# Altium schematic sheet Debug UART.SchDoc
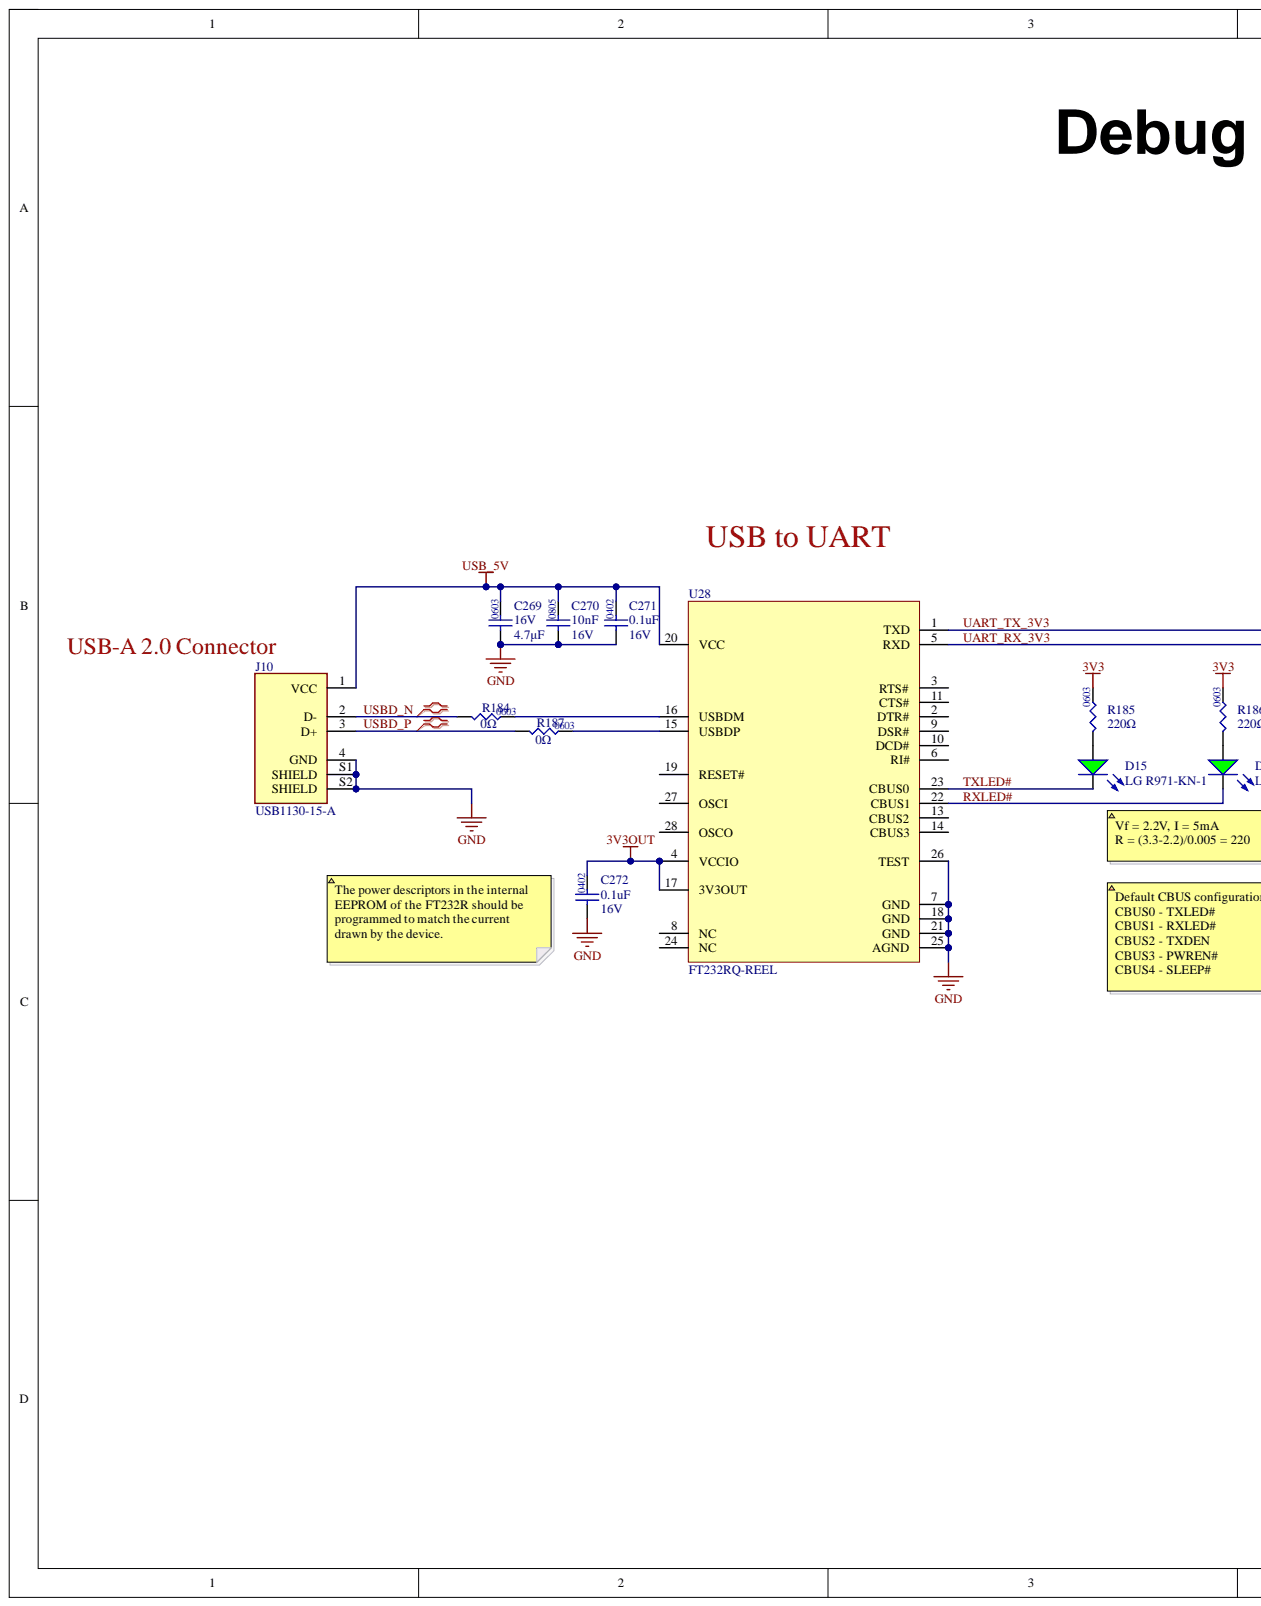
[diagram p0: Debug UART.SchDoc, left]
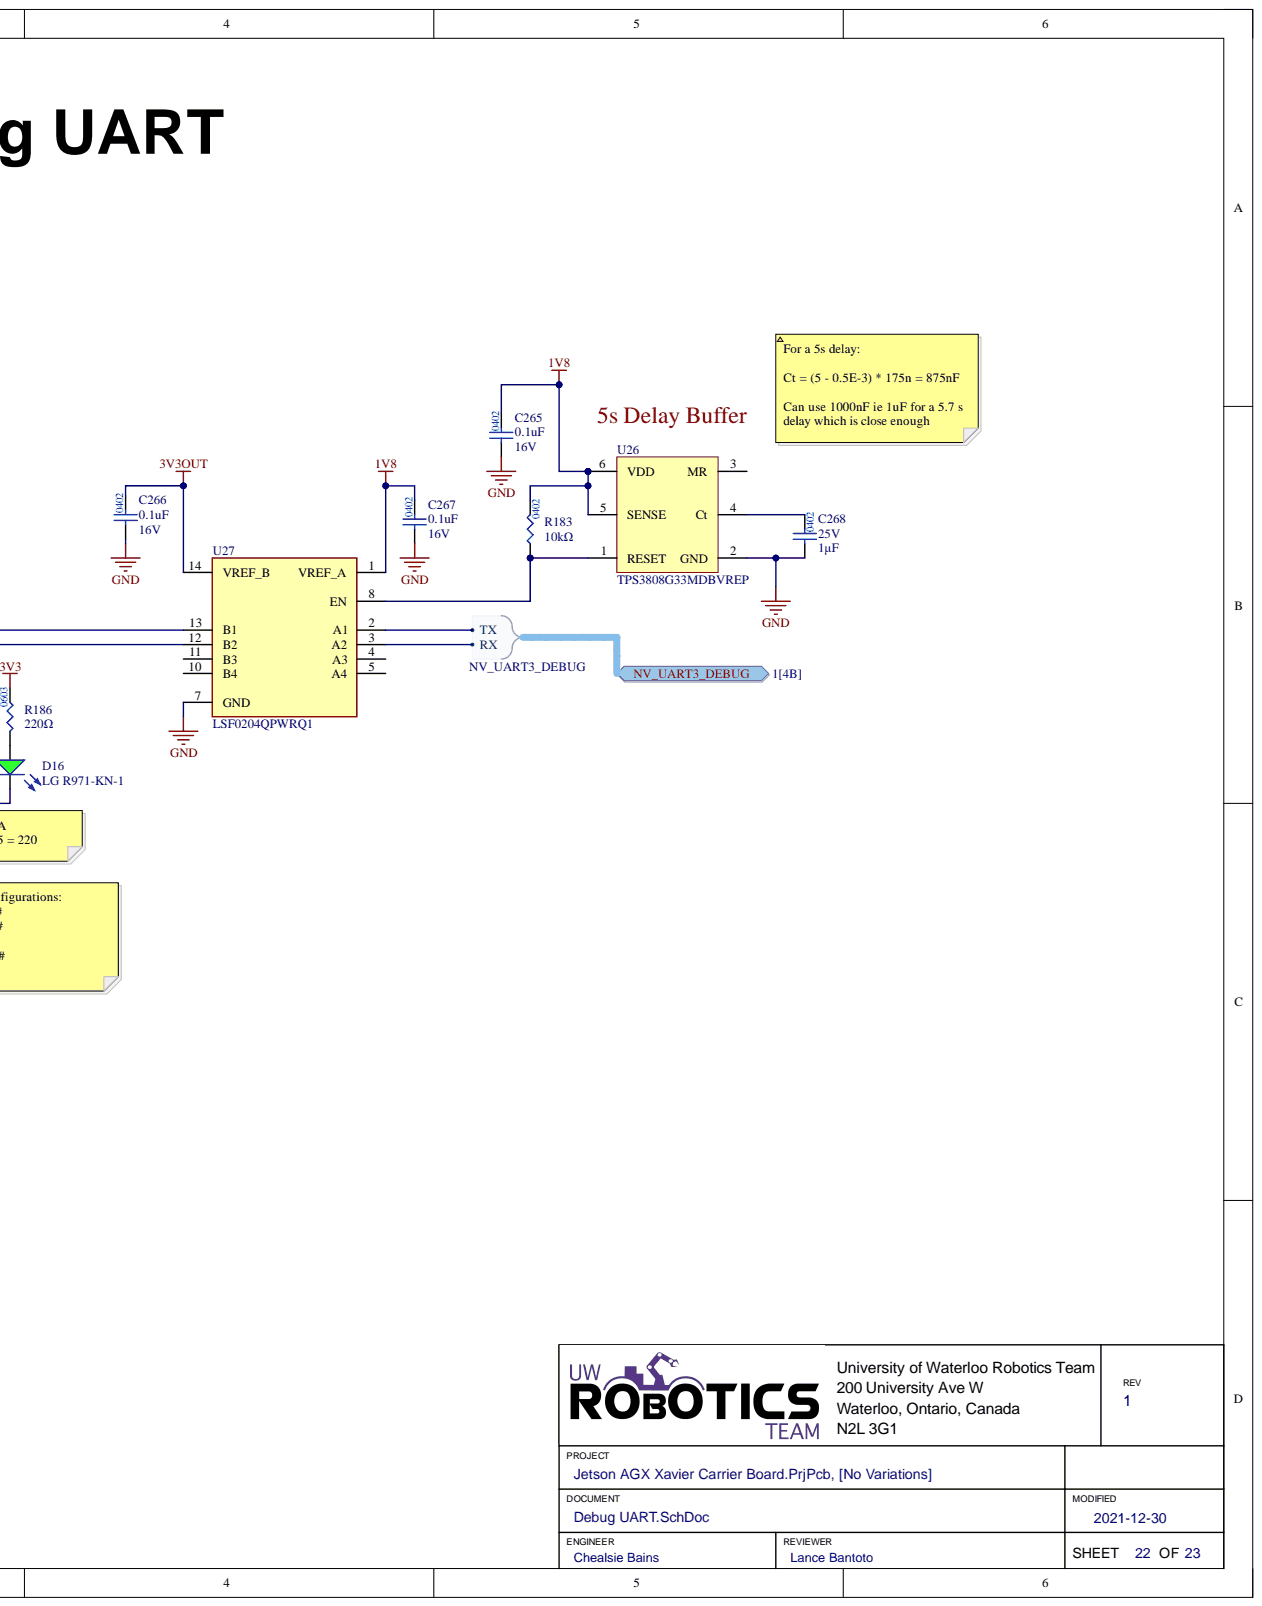
[diagram p1: Debug UART.SchDoc, right]

## Parts
C265  Capacitance: 0.1uF  Package: 0402  Voltage Rating: 16V  [CAP0402]  (hidden comment: CAP_0.1uF_16V_X7R_0402)
C266  Capacitance: 0.1uF  Package: 0402  Voltage Rating: 16V  [CAP0402]  (hidden comment: CAP_0.1uF_16V_X7R_0402)
C267  Capacitance: 0.1uF  Package: 0402  Voltage Rating: 16V  [CAP0402]  (hidden comment: CAP_0.1uF_16V_X7R_0402)
C268  value 1μF  Package: 0402  Voltage: 25V  [CAP0402]  (hidden comment: CAP_1uF_25V_0402)
C269  value 4.7μF  Package: 0603  Voltage Rating: 16V  [CAP0603]  (hidden comment: CAP_4.7uF_16V_0603)
C270  value 10nF  Voltage Rating: 16V  [CAP2012]  (hidden comment: CAP_10nF_16V_0805)
C271  Capacitance: 0.1uF  Package: 0402  Voltage Rating: 16V  [CAP0402]  (hidden comment: CAP_0.1uF_16V_X7R_0402)
C272  Capacitance: 0.1uF  Package: 0402  Voltage Rating: 16V  [CAP0402]  (hidden comment: CAP_0.1uF_16V_X7R_0402)
D15  LED_GREEN  [LED_0805_GREEN]  (value not drawn)
D16  Manufacturer Part Number 1: LG R971-KN-1  [LED_0805_GREEN]  (hidden comment: LED_GREEN)
J10  USB-A 2.0 Connector  Manufacturer Part Number 1: USB1130-15-A  [USB-A 2.0 Connector]
R183  Package: 0402  Resistance: 10kΩ  [RES0402]  (hidden comment: RES_10kΩ_0402_1%)
R184  value 0Ω  Package: 0603  [RES0603]  (hidden comment: RES_0Ω_0603)
R185  value 220Ω  Package/Footprint: 0603  [RES0603]  (hidden comment: RES_220Ω_0603)
R186  value 220Ω  Package/Footprint: 0603  [RES0603]  (hidden comment: RES_220Ω_0603)
R187  value 0Ω  Package: 0603  [RES0603]  (hidden comment: RES_0Ω_0603)
U26  TPS3808G33MDBVREP  [TPS3808G33MDBVREP]
U27  LSF0204QPWRQ1  [SOP65P640X120-14N]
U28  FT232RQ-REEL  [FT232RQ-REEL_FTD]

## Ports
NV_UART3_DEBUG, NV_UART3_DEBUG.RX, NV_UART3_DEBUG.TX

## Nets
1V8: C265.2 C267.2 R183.2 U26.5(SENSE) U26.6(VDD) U27.1(VREF_A)
3V3: R185.2 R186.2
3V3OUT: C266.2 C272.2 U27.14(VREF_B) U28.4(VCCIO) U28.17(3V3OUT)
GND: C265.1 C266.1 C267.1 C268.1 C269.1 C270.1 C271.1 C272.1 J10.4(GND) J10.S1(SHIELD) J10.S2(SHIELD) U26.2(GND) U27.7(GND) U28.7(GND) U28.18(GND) U28.21(GND) U28.25(AGND) U28.26(TEST)
USB_5V: C269.2 C270.2 C271.2 J10.1(VCC) U28.20(VCC)
RXLED#: D16.2 U28.22(CBUS1)
TXLED#: D15.2 U28.23(CBUS0)
UART_RX_3V3: U27.12(B2) U28.5(RXD)
UART_TX_3V3: U27.13(B1) U28.1(TXD)
USBD_N: J10.2(D-) R184.1
USBD_P: J10.3(D+) R187.1
NetC268_2: C268.2 U26.4(Ct)
NetD15_1: D15.1 R185.1
NetD16_1: D16.1 R186.1
NetR183_1: R183.1 U26.1(RESET) U27.8(EN)
NetR184_2: R184.2 U28.16(USBDM)
NetR187_2: R187.2 U28.15(USBDP)
NetU27_2: U27.2(A1) ; port NV_UART3_DEBUG
NetU27_3: U27.3(A2) ; port NV_UART3_DEBUG

Not connected: U26 3(MR); U27 4(A3) 5(A4) 10(B4) 11(B3); U28 2(DTR#) 3(RTS#) 6(RI#) 8(NC) 9(DSR#) 10(DCD#) 11(CTS#) 13(CBUS2) 14(CBUS3) 19(RESET#) 24(NC) 27(OSCI) 28(OSCO)

## Library data of the parts
C265-C267 C271 C272 = CAP_0.1uF_16V_X7R_0402: CAP CER 0.1UF 16V X7R 0402; Component Kind: Standard; Mounting Type: Surface Mount; Supplier 1: Digi-Key; Library Name: UWRT_Schematic_Library_2020.SchLib
C268 = CAP_1uF_25V_0402: CAP CER 1UF 25V X5R 0402; Manufacturer 1: TDK Corporation; Manufacturer Part Number 1: C1005X5R1E105K050BE; Component Kind: Standard; Supplier 1: Digi-Key; Supplier Part Number 1: 445-175215-1-ND; Library Name: UWRT_Schematic_Library_2020.SchLib
C269 = CAP_4.7uF_16V_0603: CAP CER 4.7UF 16V X6S 0603; Manufacturer 1: Murata Electronics; Manufacturer Part Number 1: GRM188C81C475KE11D; Component Kind: Standard; Dielectric: X6S; Mounting Type: SMD; Supplier 1: Digi-Key; Supplier Part Number 1: 490-13362-1-ND; Library Name: UWRT_Schematic_Library_2020.SchLib
C270 = CAP_10nF_16V_0805: CAP CER 10000PF 16V X7R 0805; Manufacturer 1: Yageo; Manufacturer Part Number 1: AC0805KRX7R7BB103; Component Kind: Standard; Supplier 1: Digi-Key; Supplier Part Number 1: 311-3161-1-ND; Library Name: UWRT_Schematic_Library_2020.SchLib
D15 = LED_GREEN: LED GREEN DIFFUSED 0805 SMD; Manufacturer 1: OSRAM; Manufacturer Part Number 1: LG R971-KN-1; Forward Voltage Drop: 2.2V; Package/Footprint: 0805; Component Kind: Standard; Mounting Type: SMD; Supplier 1: Digi-Key; Supplier Part Number 1: 475-1410-1-ND; Library Name: UWRT_Schematic_Library_2020.SchLib
D16 = LED_GREEN: LED GREEN DIFFUSED 0805 SMD; Manufacturer 1: OSRAM; Forward Voltage Drop: 2.2V; Package/Footprint: 0805; Component Kind: Standard; Mounting Type: SMD; Supplier 1: Digi-Key; Supplier Part Number 1: 475-1410-1-ND; Library Name: UWRT_Schematic_Library_2020.SchLib
J10 = USB-A 2.0 Connector: USB-A (USB TYPE-A) USB 2.0 Receptacle Connector 4 Position Through Hole, Right Angle; Manufacturer 1: GCT; Component Kind: Standard; Supplier 1: Digi-Key; Supplier Part Number 1: 2073-USB1130-15-ACT-ND; Library Name: UWRT_Schematic_Library_2020.SchLib
R183 = RES_10kΩ_0402_1%: RES 10K OHM 1% 1/16W 0402; Manufacturer 1: Samsung Electro-Mechanics; Manufacturer Part Number 1: RC1005F103CS; Component Kind: Standard; Supplier 1: Digi-Key; Supplier Part Number 1: 1276-3431-1-ND; Tolerance: 1%; Library Name: UWRT_Schematic_Library_2020.SchLib
R184 R187 = RES_0Ω_0603: RES 0 OHM JUMPER 1/10W 0603; Manufacturer 1: Yageo; Manufacturer Part Number 1: RC0603JR-070RL; Component Kind: Standard; Mounting Type: SMD; Supplier 1: Digi-Key; Supplier Part Number 1: 311-0.0GRCT-ND; Library Name: UWRT_Schematic_Library_2020.SchLib
R185 R186 = RES_220Ω_0603_5%: RES 220 OHM 5% 1/10W 0603; Manufacturer 1: Yageo; Manufacturer Part Number 1: AC0603JR-07220RL; Component Kind: Standard; Supplier 1: Digi-Key; Supplier Part Number 1: YAG3652CT-ND; Library Name: UWRT_Schematic_Library_2020.SchLib
U26 = TPS3808G33MDBVREP: IC SUPERVISOR 1 CHANNEL SOT23-6; Manufacturer 1: Texas Instruments; Component Kind: Standard; Manufacturer Part Number 1: TPS3808G33MDBVREP; Supplier 1: Digi-Key; Supplier Part Number 1: 296-23569-1-ND; Library Name: UWRT_Schematic_Library_2020.SchLib
U27 = LSF0204QPWRQ1: 4-BITS MULTI-VOLTAGE LEVEL TRANS; Manufacturer 1: Texas Instruments; Channel Type: Bidirectional; Channels per Circuit: 4; Data Rate: 100MBd; Output Type: Open Drain, Push Pull; Voltage - VCCA: 0.8V - 4.5V; Voltage - VCCB: 1.8V - 5.5V; Component Kind: Standard; Manufacturer Part Number 1: LSF0204QPWRQ1; Package: 14-TSSOP; Supplier 1: Digi-Key; Supplier Part Number 1: 296-51425-1-ND; Library Name: UWRT_Schematic_Library_2020.SchLib
U28 = FT232RQ-REEL: USB Bridge, USB to UART USB 2.0 UART Interface 32-QFN (5x5); Manufacturer: FTDI; Max Frequency: 48MHz; Max Supply Current: 100uA; Max Supply Voltage: 5.25V; Min Supply Voltage: 3.3V; Package Equivalence Code: LCC32,.2SQ,20; Qualification Status: Not Qualified; Category: Interface ICs; Component Kind: Standard; ComponentLink1Description: https://datasheet.ciiva.com:443/pdfs/VipMasterIC/IC/FTDI/FTDI-S-A0007980421/FTDI-S-A0007980421-1.pdf?src-supplier=IHS+Markit; ComponentLink1URL: https://datasheet.ciiva.com:443/pdfs/VipMasterIC/IC/FTDI/FTDI-S-A0007980421/FTDI-S-A0007980421-1.pdf?src-supplier=IHS+Markit; ComponentLink2Description: https://datasheet.ciiva.com/1505/1647396-1505445.pdf?src-supplier=Digi-Key; ComponentLink2URL: https://datasheet.ciiva.com/1505/1647396-1505445.pdf?src-supplier=Digi-Key; ComponentLink3Description: https://datasheet.ciiva.com/26841/ft232rq-reel-26841082.pdf?src-supplier=IIIC; ComponentLink3URL: https://datasheet.ciiva.com/26841/ft232rq-reel-26841082.pdf?src-supplier=IIIC; ComponentLink4Description: https://datasheet.ciiva.com/16438/ds-ft232r-16438555.pdf?src-supplier=Future+Electronics; ComponentLink4URL: https://datasheet.ciiva.com/16438/ds-ft232r-16438555.pdf?src-supplier=Future+Electronics; ComponentLink5Description: https://datasheet.ciiva.com/15150/getdatasheetpartid-644721-15150509.pdf?src-supplier=Verical; ComponentLink5URL: https://datasheet.ciiva.com/15150/getdatasheetpartid-644721-15150509.pdf?src-supplier=Verical; ComponentLink6Description: https://datasheet.ciiva.com/4787/ds-ft232r-4787663.pdf?src-supplier=Mouser; ComponentLink6URL: https://datasheet.ciiva.com/4787/ds-ft232r-4787663.pdf?src-supplier=Mouser; ComponentLink7Description: https://datasheet.ciiva.com/1787/ds-ft232r-1787041.pdf?src-supplier=Digi-Key; ComponentLink7URL: https://datasheet.ciiva.com/1787/ds-ft232r-1787041.pdf?src-supplier=Digi-Key; ComponentLink8Description: https://datasheet.ciiva.com/1787/ds-ft232r-1787042.pdf?src-supplier=Digi-Key; ComponentLink8URL: https://datasheet.ciiva.com/1787/ds-ft232r-1787042.pdf?src-supplier=Digi-Key; Height - Seated (Max): 1mm; JESD-30 Code: S-XQCC-N32; Length: 5mm; Manufacturer Part Number: FT232RQ-REEL; Max Operating Temperature: 85°C; Min Operating Temperature: -40°C; Moisture Sensitivity Level: 3; Number of Pins: 32; Package Code: HVQCCN; Package Shape: Square; Package Style: CHIP CARRIER, HEAT SINK/SLUG, VERY THIN PROFILEMeter; Pricing 1: 1=4.70000, 10=4.25000, 50=4.05000, 100=3.85000, 250=3.45000, 500=3.05000, 1000=2.85002, 2500=2.55001 (USD); REACH SVHC: Yes; RoHS: Yes; RoHS Compliant: Yes; Supplier 1: Digi-Key; Supplier Part Number 1: 768-1008-1-ND; Surface Mount: Yes; Technology: CMOS; Temperature Grade: INDUSTRIAL; Terminal Form: No Lead; Terminal Pitch: 0.5mm; Terminal Position: QUAD; Width: 5mm; Library Name: UWRT_Schematic_Library_2020.SchLib
